# Altium schematic sheet GrovePicoADK.SchDoc
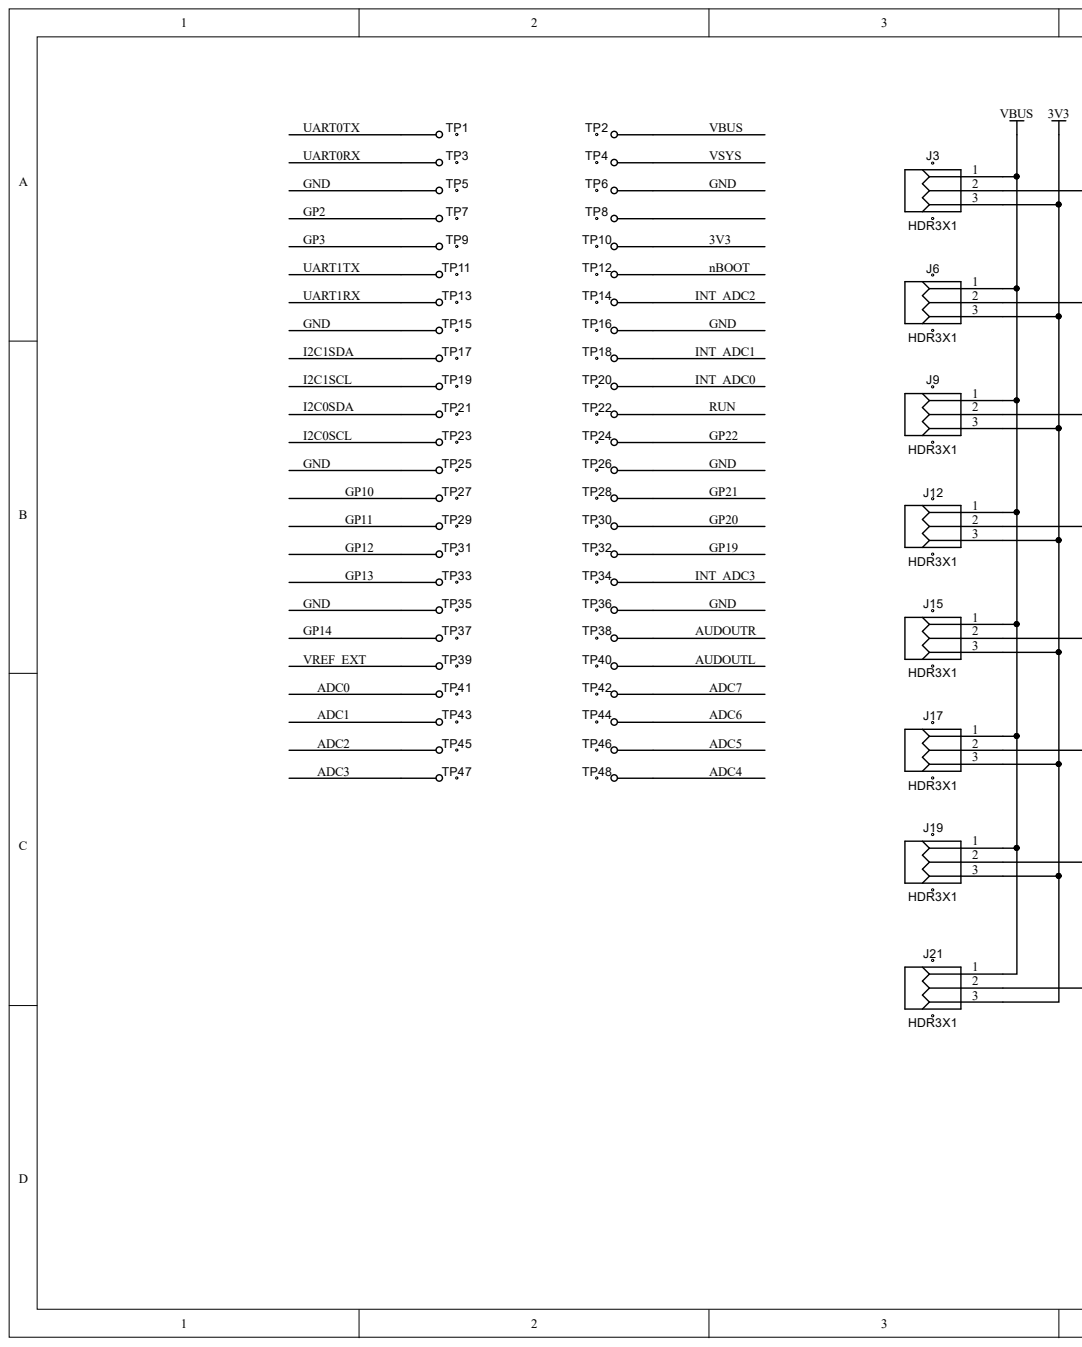
[diagram p0: GrovePicoADK.SchDoc, left]
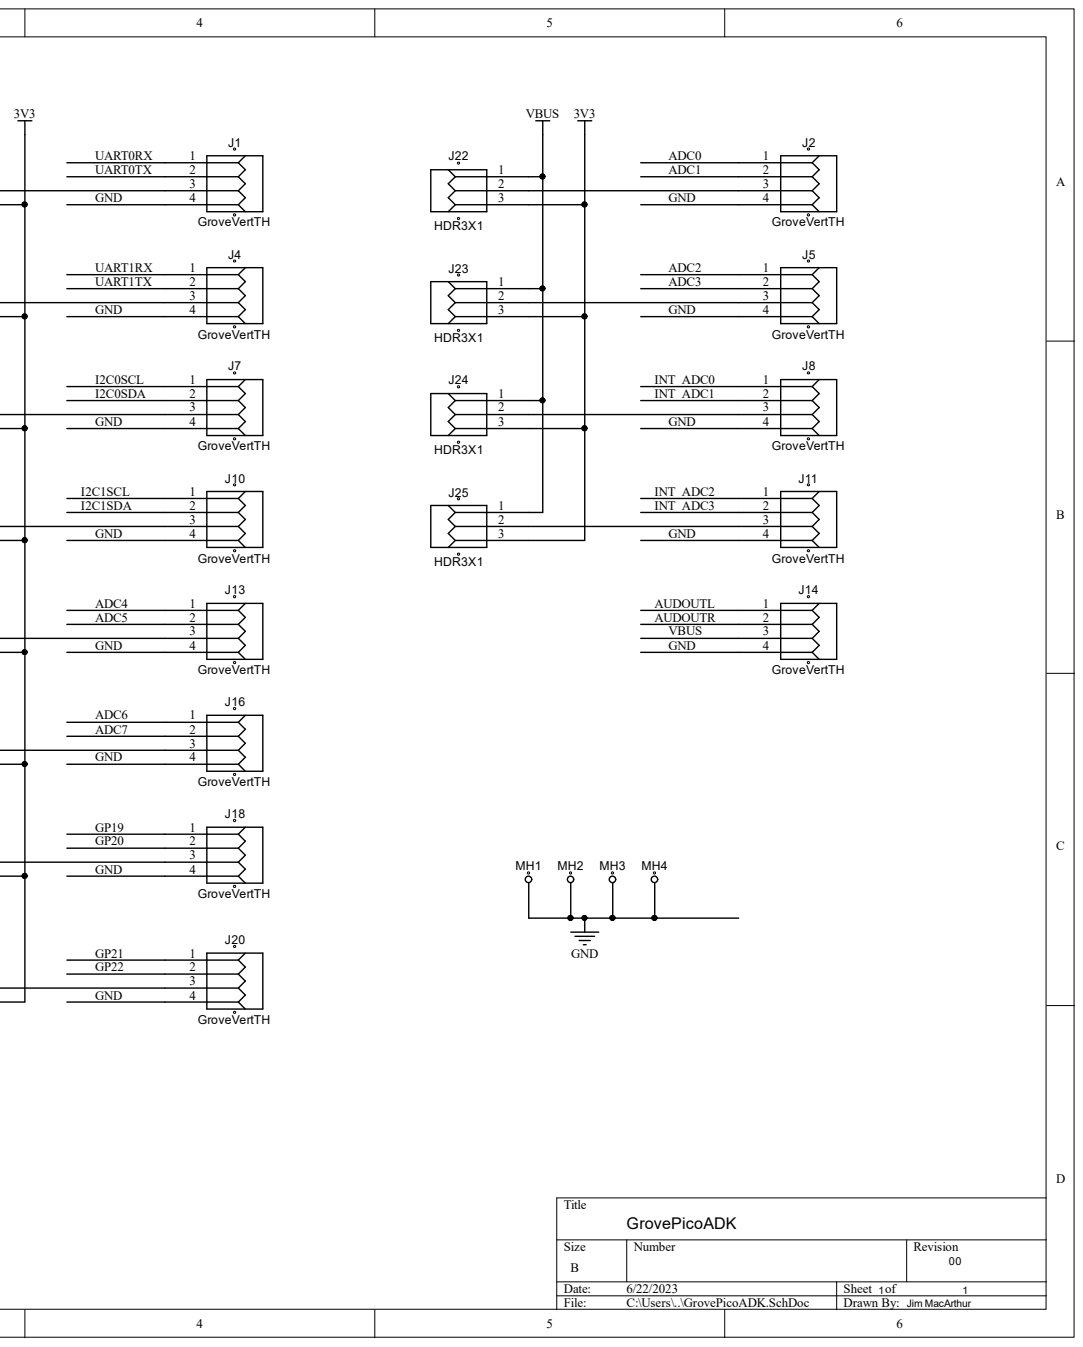
[diagram p1: GrovePicoADK.SchDoc, right]

## Parts
J1  GroveVertTH  [GroveVertTH]
J2  GroveVertTH  [GroveVertTH]
J3  HDR3X1  [HDR3X1]
J4  GroveVertTH  [GroveVertTH]
J5  GroveVertTH  [GroveVertTH]
J6  HDR3X1  [HDR3X1]
J7  GroveVertTH  [GroveVertTH]
J8  GroveVertTH  [GroveVertTH]
J9  HDR3X1  [HDR3X1]
J10  GroveVertTH  [GroveVertTH]
J11  GroveVertTH  [GroveVertTH]
J12  HDR3X1  [HDR3X1]
J13  GroveVertTH  [GroveVertTH]
J14  GroveVertTH  [GroveVertTH]
J15  HDR3X1  [HDR3X1]
J16  GroveVertTH  [GroveVertTH]
J17  HDR3X1  [HDR3X1]
J18  GroveVertTH  [GroveVertTH]
J19  HDR3X1  [HDR3X1]
J20  GroveVertTH  [GroveVertTH]
J21  HDR3X1  [HDR3X1]
J22  HDR3X1  [HDR3X1]
J23  HDR3X1  [HDR3X1]
J24  HDR3X1  [HDR3X1]
J25  HDR3X1  [HDR3X1]
MH1  MH440A  [MH440A]  (value not drawn)
MH2  MH440A  [MH440A]  (value not drawn)
MH3  MH440A  [MH440A]  (value not drawn)
MH4  MH440A  [MH440A]  (value not drawn)
TP1  TP38  [TP38]  (value not drawn)
TP2  TP38  [TP38]  (value not drawn)
TP3  TP38  [TP38]  (value not drawn)
TP4  TP38  [TP38]  (value not drawn)
TP5  TP38  [TP38]  (value not drawn)
TP6  TP38  [TP38]  (value not drawn)
TP7  TP38  [TP38]  (value not drawn)
TP8  TP38  [TP38]  (value not drawn)
TP9  TP38  [TP38]  (value not drawn)
TP10  TP38  [TP38]  (value not drawn)
TP11  TP38  [TP38]  (value not drawn)
TP12  TP38  [TP38]  (value not drawn)
TP13  TP38  [TP38]  (value not drawn)
TP14  TP38  [TP38]  (value not drawn)
TP15  TP38  [TP38]  (value not drawn)
TP16  TP38  [TP38]  (value not drawn)
TP17  TP38  [TP38]  (value not drawn)
TP18  TP38  [TP38]  (value not drawn)
TP19  TP38  [TP38]  (value not drawn)
TP20  TP38  [TP38]  (value not drawn)
TP21  TP38  [TP38]  (value not drawn)
TP22  TP38  [TP38]  (value not drawn)
TP23  TP38  [TP38]  (value not drawn)
TP24  TP38  [TP38]  (value not drawn)
TP25  TP38  [TP38]  (value not drawn)
TP26  TP38  [TP38]  (value not drawn)
TP27  TP38  [TP38]  (value not drawn)
TP28  TP38  [TP38]  (value not drawn)
TP29  TP38  [TP38]  (value not drawn)
TP30  TP38  [TP38]  (value not drawn)
TP31  TP38  [TP38]  (value not drawn)
TP32  TP38  [TP38]  (value not drawn)
TP33  TP38  [TP38]  (value not drawn)
TP34  TP38  [TP38]  (value not drawn)
TP35  TP38  [TP38]  (value not drawn)
TP36  TP38  [TP38]
TP37  TP38  [TP38]  (value not drawn)
TP38  TP38  [TP38]
TP39  TP38  [TP38]  (value not drawn)
TP40  TP38  [TP38]
TP41  TP38  [TP38]  (value not drawn)
TP42  TP38  [TP38]  (value not drawn)
TP43  TP38  [TP38]  (value not drawn)
TP44  TP38  [TP38]  (value not drawn)
TP45  TP38  [TP38]  (value not drawn)
TP46  TP38  [TP38]  (value not drawn)
TP47  TP38  [TP38]  (value not drawn)
TP48  TP38  [TP38]  (value not drawn)

## Nets
3V3: J3.3 J6.3 J9.3 J12.3 J15.3 J17.3 J19.3 J21.3 J22.3 J23.3 J24.3 J25.3 TP10.1
ADC0: J2.1 TP41.1
ADC1: J2.2 TP43.1
ADC2: J5.1 TP45.1
ADC3: J5.2 TP47.1
ADC4: J13.1 TP48.1
ADC5: J13.2 TP46.1
ADC6: J16.1 TP44.1
ADC7: J16.2 TP42.1
AUDOUTL: J14.1 TP40.1
AUDOUTR: J14.2 TP38.1
GND: J1.4 J2.4 J4.4 J5.4 J7.4 J8.4 J10.4 J11.4 J13.4 J14.4 J16.4 J18.4 J20.4 MH1.1 MH2.1 MH3.1 MH4.1 TP5.1 TP6.1 TP15.1 TP16.1 TP25.1 TP26.1 TP35.1 TP36.1
GP2: TP7.1
GP3: TP9.1
GP10: TP27.1
GP11: TP29.1
GP12: TP31.1
GP13: TP33.1
GP14: TP37.1
GP19: J18.1 TP32.1
GP20: J18.2 TP30.1
GP21: J20.1 TP28.1
GP22: J20.2 TP24.1
I2C0SCL: J7.1 TP23.1
I2C0SDA: J7.2 TP21.1
I2C1SCL: J10.1 TP19.1
I2C1SDA: J10.2 TP17.1
INT_ADC0: J8.1 TP20.1
INT_ADC1: J8.2 TP18.1
INT_ADC2: J11.1 TP14.1
INT_ADC3: J11.2 TP34.1
NBOOT: TP12.1
RUN: TP22.1
UART0RX: J1.1 TP3.1
UART0TX: J1.2 TP1.1
UART1RX: J4.1 TP13.1
UART1TX: J4.2 TP11.1
VBUS: J3.1 J6.1 J9.1 J12.1 J14.3 J15.1 J17.1 J19.1 J21.1 J22.1 J23.1 J24.1 J25.1 TP2.1
VREF_EXT: TP39.1
VSYS: TP4.1
NetJ1_3: J1.3 J3.2
NetJ2_3: J2.3 J22.2
NetJ4_3: J4.3 J6.2
NetJ5_3: J5.3 J23.2
NetJ7_3: J7.3 J9.2
NetJ8_3: J8.3 J24.2
NetJ10_3: J10.3 J12.2
NetJ11_3: J11.3 J25.2
NetJ13_3: J13.3 J15.2
NetJ16_3: J16.3 J17.2
NetJ18_3: J18.3 J19.2
NetJ20_3: J20.3 J21.2

Not connected: TP8 1

## Library data of the parts
J1 J2 J4 J5 J7 J8 J10 J11 J13 J14 J16 J18 J20 = GroveVertTH: vertical thru-hole Grove connector; Component Kind: Standard; Library Name: JMAC.IntLib
MH1-MH4 = MH440A: Value: {Value}; Component Kind: Standard; Description: MH440A; Library Name: JMAC.IntLib
J3 J6 J9 J12 J15 J17 J19 J21-J25 = HDR3X1: Component Kind: Standard; Description: HDR3X1; Library Name: JMAC.IntLib
TP1-TP48 = TP38: Component Kind: Standard; Description: TP38; Library Name: JMAC.IntLib
